# Altium schematic sheet driver.SchDoc(driver)
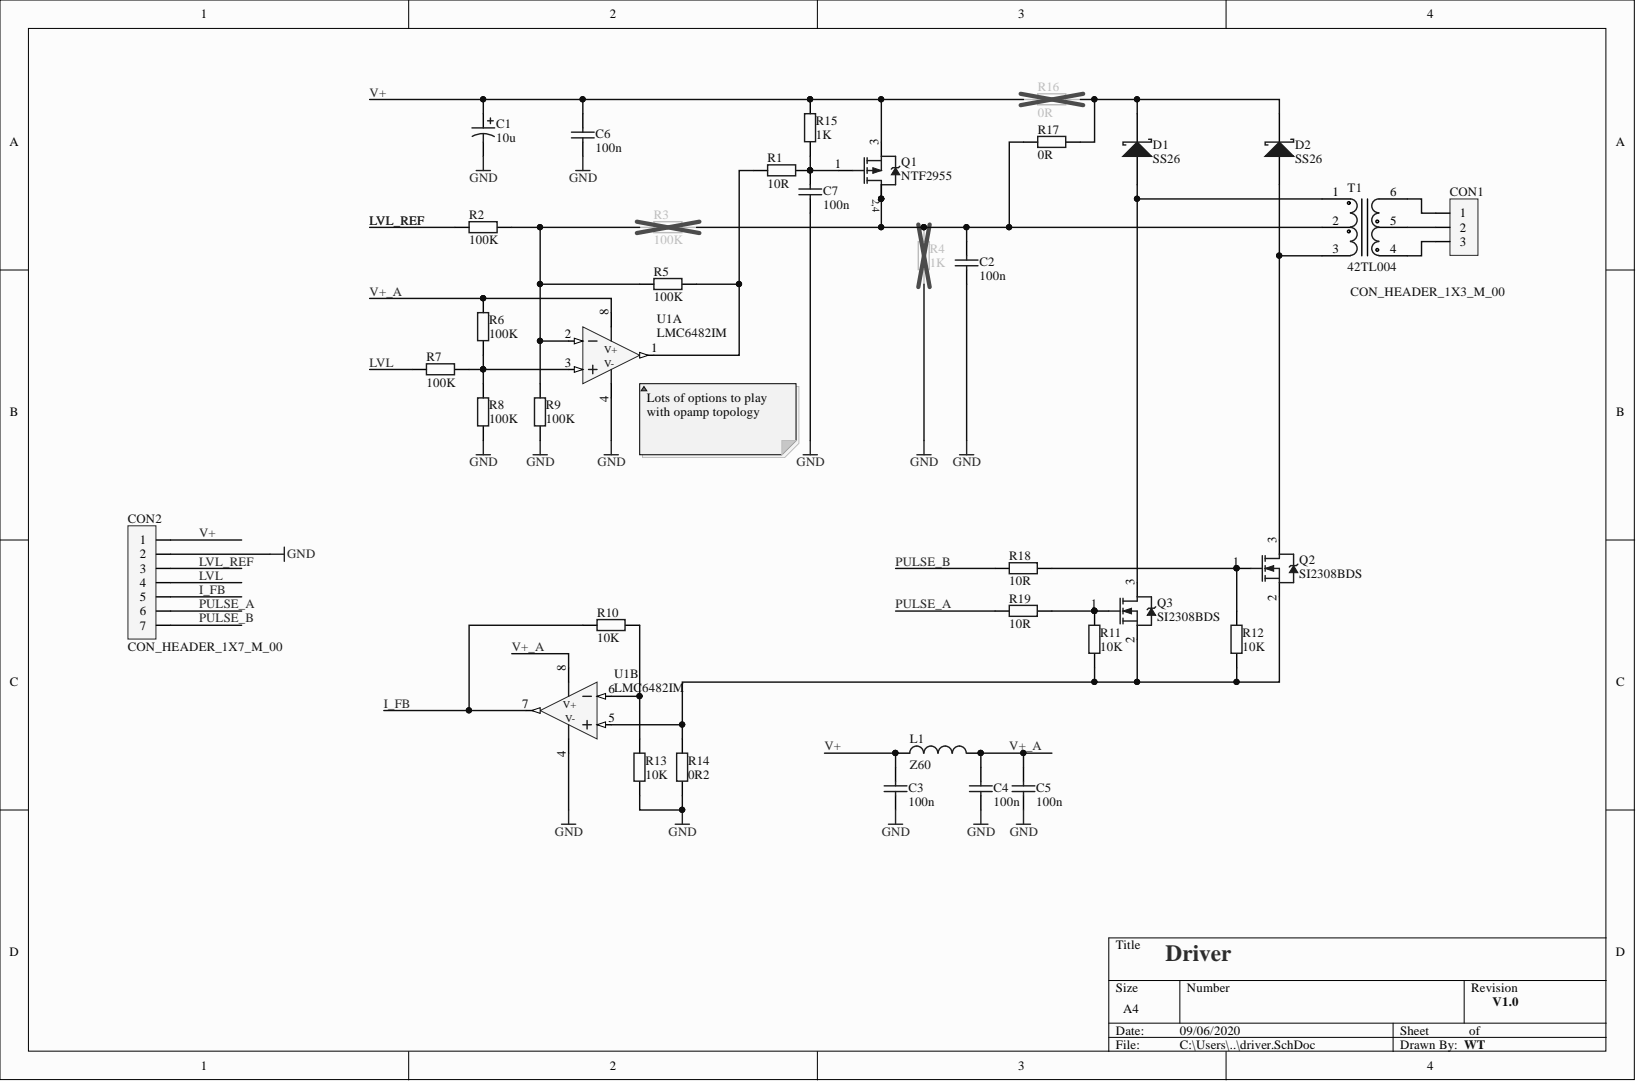
[diagram p0: driver.SchDoc]

## Parts
C1  10u  [CAPAE430X580[B]]
C2  100n  [CAPC1608[0603]N]
C3  100n  [CAPC1608[0603]N]
C4  100n  [CAPC1608[0603]N]
C5  100n  [CAPC1608[0603]N]
C6  100n  [CAPC1608[0603]N]
C7  100n  [CAPC1608[0603]N]
CON1  CON_HEADER_1X3_M_00  [CON_HEADER_1X3_M_00]  (value not drawn)
CON2  CON_HEADER_1X7_M_00  [CON_HEADER_1X7_M_00]  (value not drawn)
D1  SS26  [DO214AA(SMB)]
D2  SS26  [DO214AA(SMB)]
L1  Z60  [INDC1608[0603]N]
Q1  NTF2955  [SOT223(SOT230P700-4M)]
Q2  SI2308BDS  [SOT23]
Q3  SI2308BDS  [SOT23]
R1  10R  [RESC1608[0603]N]
R2  100K  [RESC1608[0603]N]
R3  100K  [RESC1608[0603]N]
R4  1K  [RESC1608[0603]N]
R5  100K  [RESC1608[0603]N]
R6  100K  [RESC1608[0603]N]
R7  100K  [RESC1608[0603]N]
R8  100K  [RESC1608[0603]N]
R9  100K  [RESC1608[0603]N]
R10  10K  [RESC1608[0603]N]
R11  10K  [RESC1608[0603]N]
R12  10K  [RESC1608[0603]N]
R13  10K  [RESC1608[0603]N]
R14  0R2  [RESC3216[1206]M]
R15  1K  [RESC1608[0603]N]
R16  0R  [RESC1608[0603]N]
R17  0R  [RESC1608[0603]N]
R18  10R  [RESC1608[0603]N]
R19  10R  [RESC1608[0603]N]
T1  42TL004  [42TL-Series]
U1  LMC6482IM  [SOIC127P600-8_M]  (parts A,B)

## Nets
GND: C1.2 C2.1 C3.1 C4.1 C5.1 C6.1 C7.1 CON2.2 R4.2 R8.1 R9.2 R13.1 R14.1 U1.4(V-)
I_FB: CON2.5 R10.1 U1.7
LVL: CON2.4 R7.1
LVL_REF: CON2.3 R2.2
PULSE_A: CON2.6 R19.1
PULSE_B: CON2.7 R18.1
V+: C1.1 C3.2 C6.2 CON2.1 L1.1 Q1.3 R15.1 R16.1
V+_A: C4.2 C5.2 L1.2 R6.2 U1.8(V+)
NetC2_2: C2.2 Q1.2 Q1.4 R3.2 R4.1 R17.1 T1.2
NetC7_2: C7.2 Q1.1 R1.2 R15.2
NetCON1_1: CON1.1 T1.6
NetCON1_2: CON1.2 T1.5
NetCON1_3: CON1.3 T1.4
NetD1_1: D1.1 D2.1 R16.2 R17.2
NetD1_2: D1.2 Q3.3 T1.1
NetD2_2: D2.2 Q2.3 T1.3
NetQ2_1: Q2.1 R12.2 R18.2
NetQ2_2: Q2.2 Q3.2 R11.1 R12.1 R14.2 U1.5
NetQ3_1: Q3.1 R11.2 R19.2
NetR1_1: R1.1 R5.2 U1.1
NetR2_1: R2.1 R3.1 R5.1 R9.1 U1.2
NetR6_1: R6.1 R7.2 R8.2 U1.3
NetR10_2: R10.2 R13.2 U1.6

## Library data of the parts
C1 = CAPAE_10u_4.3x5.8_25V: CAPACITOR Aluminum Electrolytic 10uF; 25V; SMD, 4.3x4.3mm, H5.8mm; Low ESR; Tolerance. +/-20%; Temp.op. -40°C to +105°C; Manufacturer P/N: EEEFK1E100R; Manufacturer: PANASONIC; Value: 10000000pF; Fast Track: No; CIN: 121-400-054; AMOUNT: 1.000; Voltage: 25V; Supplier Part Number 1: 9695729; Supplier 1: Farnell; Simulation: CAP; Signal Integrity: Cap Pol; Published: 2007-08-09; MLX P/N: CAPAE_10u_4.3x5.8_25V; FMEA_TYPE: CAPACITOR; Delivery-XPEQT: No; ComponentLink1URL: http://cvs.tess.elex.be/cgi-bin/cvsweb/~checkout~/business_units/technology_development/HW_Groups/datasheets/Capacitors/SMD_Elyt/CAP_Panasonic_FK.pdf?rev=1.1; ComponentLink1Description: Datasheet [PDF]; Component Kind: Standard; Publisher: PDS; Library Name: CapacitorElytSMD.IntLib
C2-C7 = CAPC_100n_0603: CAPACITOR Ceramic Multi-Layer X7R; 100nF; 50V; 0603; Tolerance, +/-10%; Temp, op. -55°C to +125°C; Manufacturer P/N: CL10B104KBNC; Manufacturer: Samsung; Value: 100000p; Fast Track: Yes; Delivery-XPEQT: No; CIN: 121-100-067; AMOUNT: 1.000; Voltage: 50V; Supplier Part Number 1: C0603 100nF 50V X7R SAMSUNG; Supplier 1: Comet Electronics; Simulation: CAP; Signal Integrity: Cap; Published: 2007-07-31; MLX P/N: CAPC_100n_0603; Library Name: CapacitorCer0603.IntLib; FMEA_TYPE: CAPACITOR; ComponentLink1URL: http://cvs.tess.elex.be/cgi-bin/cvsweb/~checkout~/business_units/technology_development/HW_Groups/datasheets/Capacitors/SMD_Ceramic/smccp.pdf?rev=1.1; ComponentLink1Description: Datasheet [PDF]; Component Kind: Standard; Publisher: PDS
CON1 = CON_HEADER_1X3_M_00: Header, 1x3 pins, pitch 2.54mm, Male, Straight; FMEA_TYPE: CONNECTOR; Fast Track: No; CIN: 142-200-388; AMOUNT: 1.000; Published: 2007-10-03; MLX P/N: CON_HEADER_1X3_M_00; Library Name: Connectors.IntLib; Delivery-XPEQT: No; ComponentLink1URL: http://cvs.tess.elex.be/cgi-bin/cvsweb/business_units/technology_development/HW_Groups/datasheets/connectors/HEADER_SIL_M_00_FCI.pdf?rev=1.1; ComponentLink1Description: Datasheet [PDF]; Component Kind: Standard; Publisher: SLE
CON2 = CON_HEADER_1X7_M_00: Header, 1x7 pins, pitch 2.54mm, Male, Straight; FMEA_TYPE: CONNECTOR; Fast Track: No; CIN: 142-200-388; AMOUNT: 1.000; Published: 2010-02-25; MLX P/N: CON_HEADER_1X7_M_00; Library Name: Connectors.IntLib; Delivery-XPEQT: No; ComponentLink1URL: http://cvs.tess.elex.be/cgi-bin/cvsweb/business_units/technology_development/HW_Groups/datasheets/connectors/HEADER_SIL_M_00_FCI.pdf?rev=1.1; ComponentLink1Description: Datasheet [PDF]; Component Kind: Standard; Publisher: SLE
D1 D2 = SS26: Schottky Rectifier If=2A, Vr=60V; Manufacturer P/N: SS26-E3/52T; Manufacturer: Vishay; FMEA_TYPE: DIODE; Fast Track: No; CIN: 111-110-029; AMOUNT: 1.000; Supplier Part Number 1: 1189770; Supplier 1: Farnell; Published: 2011-03-14; MLX P/N: SS26; Library Name: Semiconductor_Discrete.IntLib; Delivery-XPEQT: No; ComponentLink1URL: http://cvs.tess.elex.be/cgi-bin/cvsweb/~checkout~/business_units/technology_development/HW_Groups/datasheets/Exotic/SS26.pdf?rev=1.1; ComponentLink1Description: Datasheet [PDF]; Component Kind: Standard; Publisher: vso
L1 = FB_Z60_0603: Ferrite Bead 0603; Z=60ohm; Current=300mA, R=0.2ohm; Value: 60ohm; FMEA_TYPE: INDUCTANCE; Fast Track: No; Current max DC: 300mA; CIN: 124-000-025; AMOUNT: 1.000; Supplier Part Number 1: 3056491RL; Supplier 1: Farnell; Simulation: INDUCTOR; Signal Integrity: Inductor; Published: 2007-05-18; MLX P/N: FB_Z60_0603; Library Name: Inductance.IntLib; Delivery-XPEQT: No; ComponentLink1URL: http://cvs.tess.elex.be/cgi-bin/cvsweb/~checkout~/business_units/technology_development/HW_Groups/datasheets/Inductance/SMD/FB_SMD.pdf?rev=1.1; ComponentLink1Description: Datasheet [PDF]; Component Kind: Standard; Publisher: PDS
Q1 = NTF2955: P-Channel MOSFET Vds=60V, Id=2A, Rdson=0.185R; Manufacturer P/N: NTF2955T1G; Manufacturer: ON Semiconductor; FMEA_TYPE: TRANSISTOR; Fast Track: No; CIN: 111-230-083; AMOUNT: 1.000; Supplier Part Number 1: 1453649; Supplier 1: Farnell; Published: 2011-06-07; MLX P/N: NTF2955; Library Name: Semiconductor_Discrete.IntLib; Delivery-XPEQT: No; ComponentLink1URL: http://cvs.tess.elex.be/cgi-bin/cvsweb/~checkout~/business_units/technology_development/HW_Groups/datasheets/semiconductors/NTF2955.pdf?rev=1.1; ComponentLink1Description: Datasheet [PDF]; Component Kind: Standard; Publisher: vso
Q2 Q3 = SI2308BDS: N-Channel MOSFET Vds=60V, Id=1.5A, Rdson=0.192R; FMEA_TYPE: TRANSISTOR; Fast Track: No; CIN: 000-000-000; AMOUNT: 1.000; Published: 2011-09-07; MLX P/N: SI2308BDS; Library Name: Semiconductor_Discrete.IntLib; Delivery-XPEQT: No; ComponentLink1URL: http://cvs.tess.elex.be/cgi-bin/cvsweb/~checkout~/business_units/technology_development/HW_Groups/datasheets/semiconductors/SI2308BDS.pdf?rev=1.1; ComponentLink1Description: Datasheet [PDF]; Component Kind: Standard; Publisher: vso
R1 R18 R19 = RESC_10R_0603: Resistor Thick Film; 10R; 0603; 0.1W; 1%; 50V; 200ppm/DegC; Fast Track: No; CIN: 122-110-144; AMOUNT: 1.000; Value: 10R; Tolerance: 1%; Simulation: RESISTOR; Signal Integrity: Res; Published: 2008-04-17; MLX P/N: RESC_10R_0603; Library Name: Resistor0603.IntLib; FMEA_TYPE: RESISTOR; Delivery-XPEQT: No; ComponentLink1URL: http://cvs.tess.elex.be/cgi-bin/cvsweb/~checkout~/business_units/technology_development/HW_Groups/datasheets/Resistors/SMD/SMD_Resistors.pdf?rev=1.1; ComponentLink1Description: Datasheet [PDF]; Component Kind: Standard; Publisher: PDS
R2 R3 R5-R9 = RESC_100K_0603: Resistor Thick Film; 100K; 0603; 0.1W; 1%; 50V; 100ppm/DegC; Manufacturer: UniOhm; Value: 100000R; Fast Track: No; CIN: 122-110-179; AMOUNT: 1.000; Tolerance: 1%; Supplier Part Number 1: R0603 100K 1%; Supplier 1: Comet Electronics; Simulation: RESISTOR; Signal Integrity: Res; Published: 2008-04-17; MLX P/N: RESC_100K_0603; Library Name: Resistor0603.IntLib; FMEA_TYPE: RESISTOR; Delivery-XPEQT: No; ComponentLink1URL: http://cvs.tess.elex.be/cgi-bin/cvsweb/~checkout~/business_units/technology_development/HW_Groups/datasheets/Resistors/SMD/SMD_Resistors.pdf?rev=1.1; ComponentLink1Description: Datasheet [PDF]; Component Kind: Standard; Publisher: PDS
R4 R15 = RESC_1K_0603: Resistor Thick Film; 1K; 0603; 0.1W; 1%; 50V; 100ppm/DegC; Value: 1000R; Fast Track: No; CIN: 122-110-174; AMOUNT: 1.000; Tolerance: 1%; Supplier Part Number 1: R0603 1.0K 1%; Supplier 1: Comet Electronics; Simulation: RESISTOR; Signal Integrity: Res; Published: 2008-04-17; MLX P/N: RESC_1K_0603; Library Name: Resistor0603.IntLib; FMEA_TYPE: RESISTOR; Delivery-XPEQT: No; ComponentLink1URL: http://cvs.tess.elex.be/cgi-bin/cvsweb/~checkout~/business_units/technology_development/HW_Groups/datasheets/Resistors/SMD/SMD_Resistors.pdf?rev=1.1; ComponentLink1Description: Datasheet [PDF]; Component Kind: Standard; Publisher: PDS
R10-R13 = RESC_10K_0603: Resistor Thick Film; 10K; 0603; 0.1W; 1%; 50V; 100ppm/DegC; Value: 10000R; Fast Track: No; CIN: 122-110-176; AMOUNT: 1.000; Tolerance: 1%; Supplier Part Number 1: 9238603; Supplier 1: Farnell; Simulation: RESISTOR; Signal Integrity: Res; Published: 2008-04-17; MLX P/N: RESC_10K_0603; Library Name: Resistor0603.IntLib; FMEA_TYPE: RESISTOR; Delivery-XPEQT: No; ComponentLink1URL: http://cvs.tess.elex.be/cgi-bin/cvsweb/~checkout~/business_units/technology_development/HW_Groups/datasheets/Resistors/SMD/SMD_Resistors.pdf?rev=1.1; ComponentLink1Description: Datasheet [PDF]; Component Kind: Standard; Publisher: PDS
R14 = RESC_0R2_1206_0.5W: Resistor Thick Film; 0R2; 1206; 0.5W; 1%; 200V; 100ppm/DegC; Fast Track: No; CIN: 000-000-000; AMOUNT: 1.000; Value: 0R2; Tolerance: 1%; Supplier Part Number 1: CSR1206FKR200CT-ND; Supplier 1: Digi-key; Simulation: RESISTOR; Signal Integrity: Res; Published: 2019-10-10; MLX P/N: RESC_0R2_1206_0.5W; FMEA_TYPE: RESISTOR; Delivery-XPEQT: No; ComponentLink1URL: https://gitlab.melexis.com/tcc-hardware/HW_Templates_Altium/AD_Datasheets/blob/master/Resistors/Resistors_Stackpole_CSR_CSRN_Series.pdf; ComponentLink1Description: Datasheet [PDF]; Component Kind: Standard; Publisher: DTL; Library Name: Resistor_Exotic.IntLib
R16 R17 = RESC_0R_0603: Resistor Thick Film; 0R; 0603; 0.1W; 1%; 50V; 200ppm/DegC; Fast Track: Yes; Delivery-XPEQT: No; CIN: 122-110-167; AMOUNT: 1.000; Value: 0R; Tolerance: 1%; Simulation: RESISTOR; Signal Integrity: Res; Published: 2008-04-17; MLX P/N: RESC_0R_0603; Library Name: Resistor0603.IntLib; FMEA_TYPE: RESISTOR; ComponentLink1URL: http://cvs.tess.elex.be/cgi-bin/cvsweb/~checkout~/business_units/technology_development/HW_Groups/datasheets/Resistors/SMD/SMD_Resistors.pdf?rev=1.1; ComponentLink1Description: Datasheet [PDF]; Component Kind: Standard; Publisher: PDS
T1 = 42LT004: Miniature audio transformer; ComponentLink2URL: https://www.mouser.be/datasheet/2/449/Yuetone_XC-600127-1212403.pdf; ComponentLink2Description: Datasheet; ComponentLink1URL: https://www.mouser.be/ProductDetail/Xicon/42TL004-RC?qs=%252BLh6ltJumVRX87vpOY3zCA%3D%3D; ComponentLink1Description: Supplier; Component Kind: Standard; Library Name: Melexis_bot.IntLib
U1 = LMC6482IM: Dual, CMOS Rail-To-Rail Input and Output Op Amp; FMEA_TYPE: IC; Fast Track: No; CIN: 000-000-000; AMOUNT: 1.000; Published: 2007-09-12; MLX P/N: LMC6482IM; Library Name: Semiconductor_IC.IntLib; Delivery-XPEQT: No; ComponentLink1URL: http://cvs.tess.elex.be/cgi-bin/cvsweb/~checkout~/business_units/technology_development/HW_Groups/datasheets/semiconductors/LMC6482.pdf?rev=1.1; ComponentLink1Description: Datasheet [PDF]; Component Kind: Standard; Publisher: vso
